# Altium schematic sheet Main.SchDoc(U_Main)
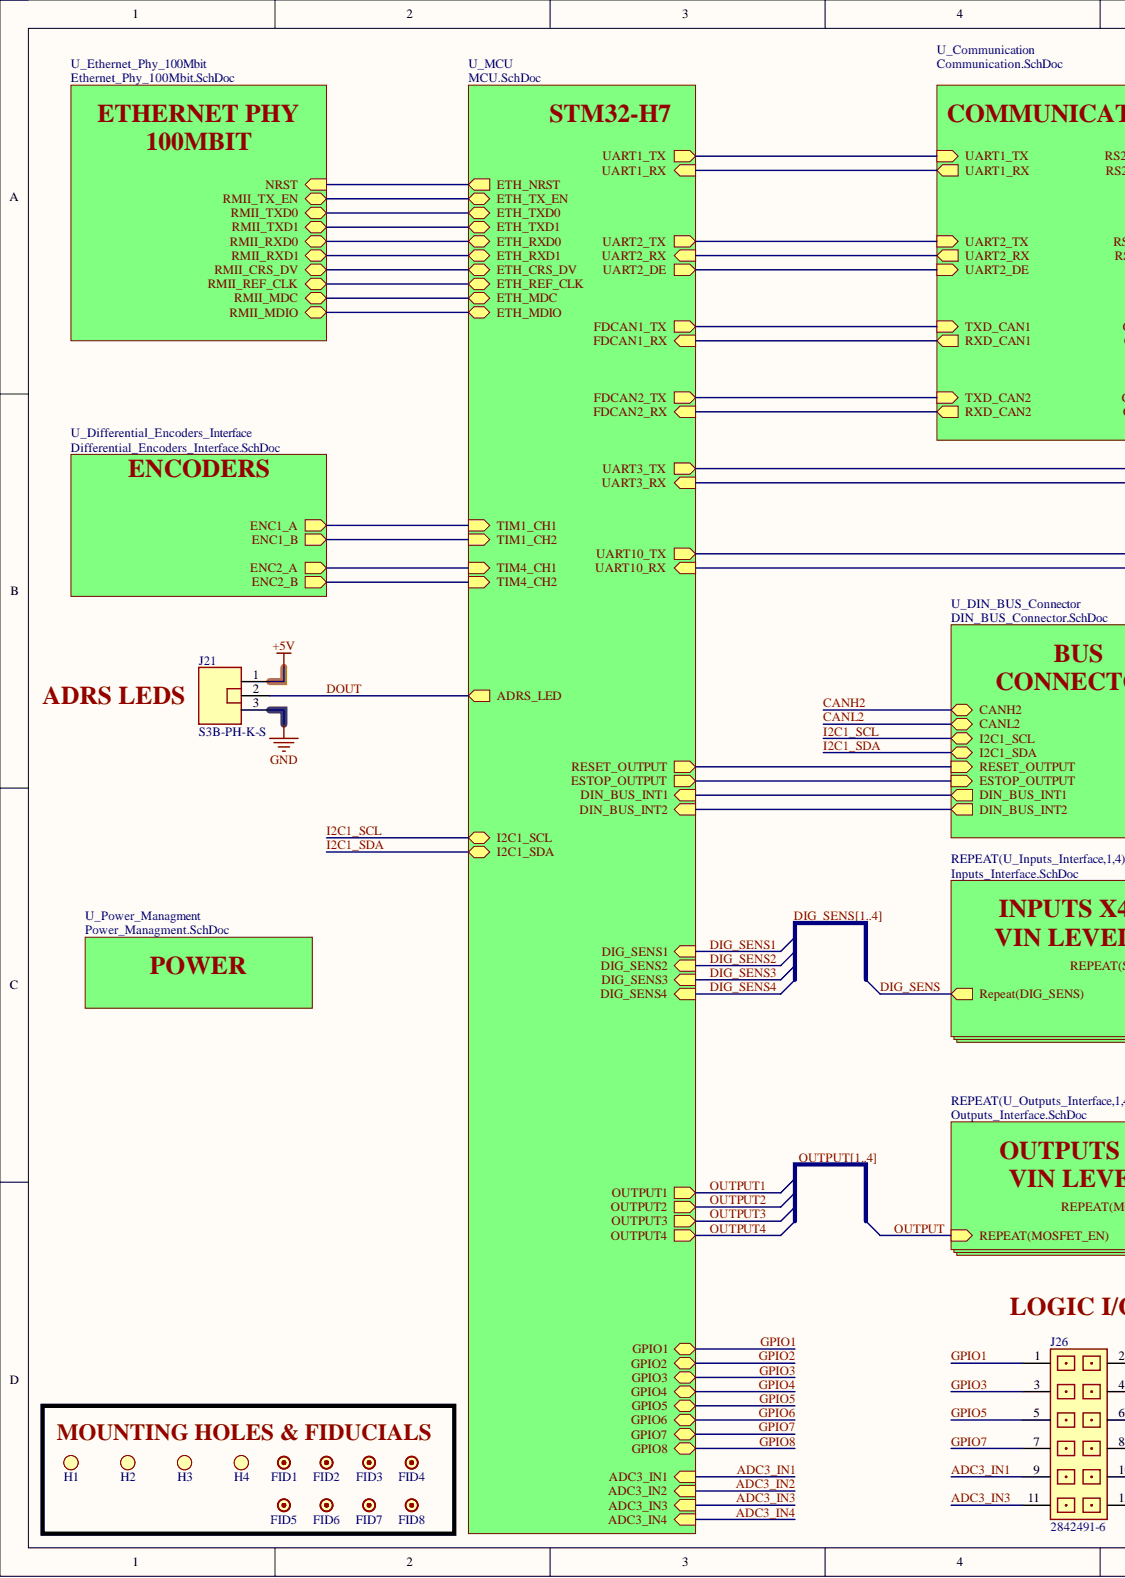
[diagram p0: Main.SchDoc, left]
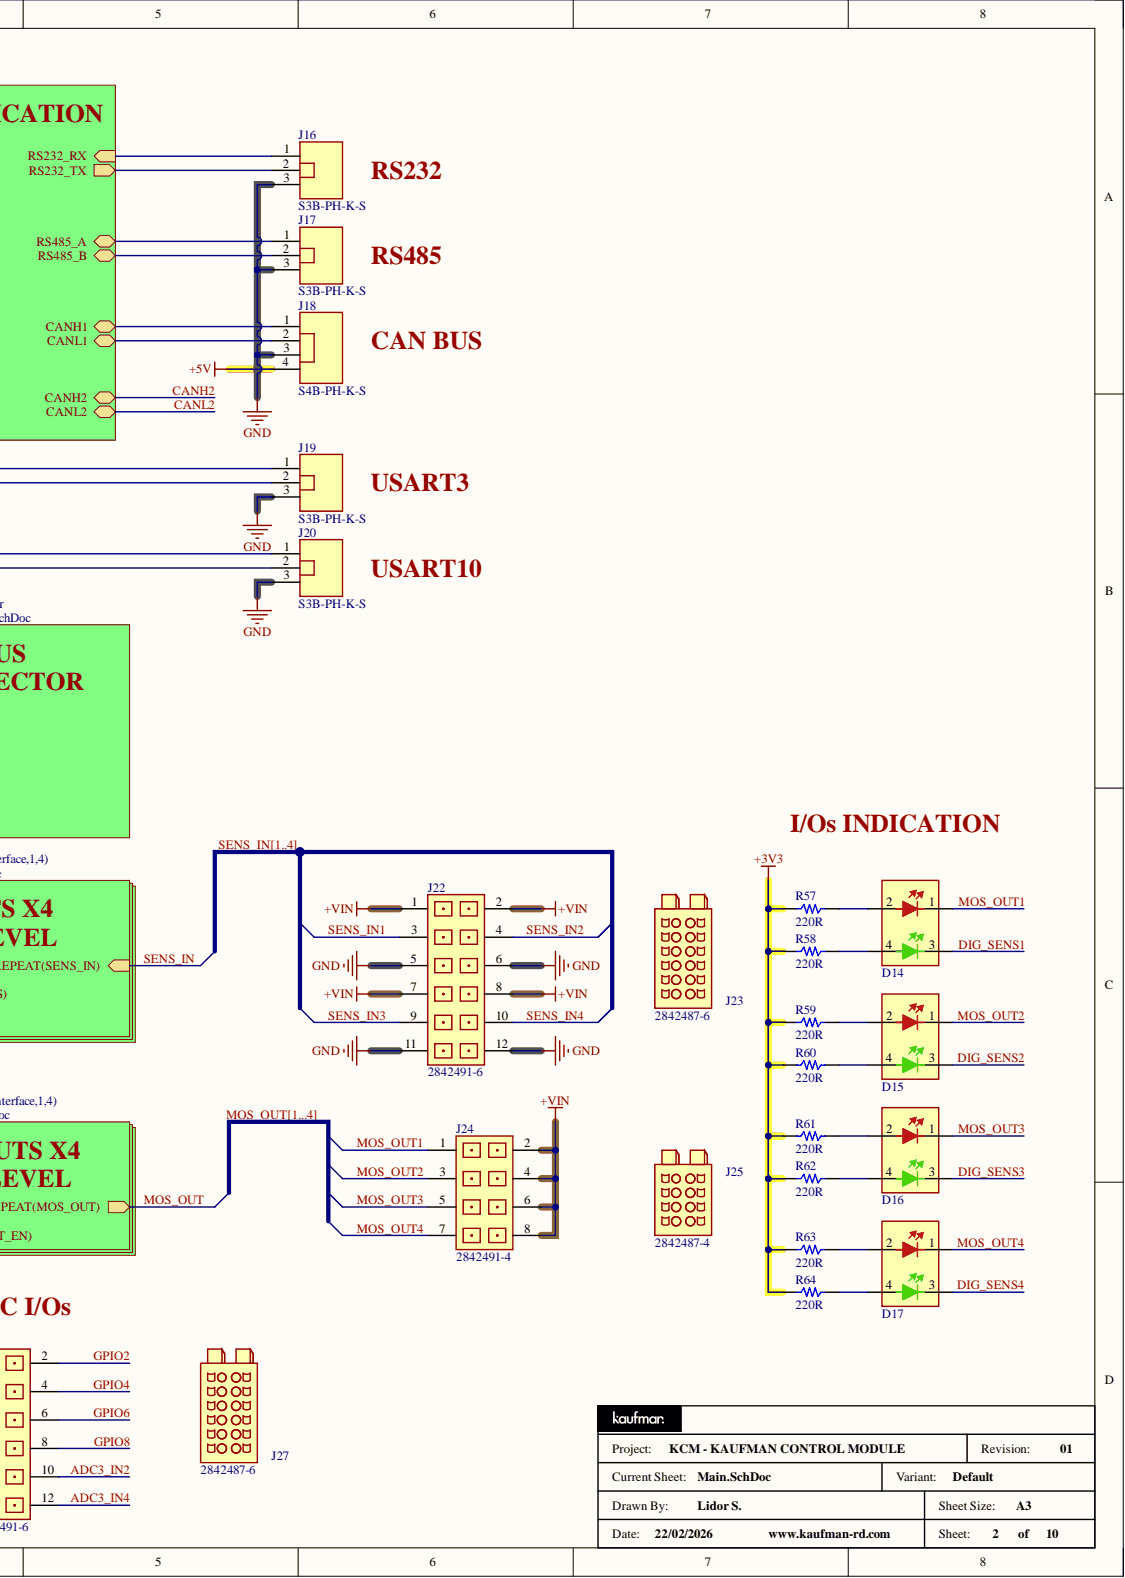
[diagram p1: Main.SchDoc, right]

## Parts
D14  WP934MD/LILGD  [WP934MD/LILGD]  (value not drawn)
D15  WP934MD/LILGD  [WP934MD/LILGD]  (value not drawn)
D16  WP934MD/LILGD  [WP934MD/LILGD]  (value not drawn)
D17  WP934MD/LILGD  [WP934MD/LILGD]  (value not drawn)
FID1  FIDUCIAL  [FIDUCIAL - 1mm]  (no BOM)
FID2  FIDUCIAL  [FIDUCIAL - 1mm]  (no BOM)
FID3  FIDUCIAL  [FIDUCIAL - 1mm]  (no BOM)
FID4  FIDUCIAL  [FIDUCIAL - 1mm]  (no BOM)
FID5  FIDUCIAL  [FIDUCIAL - 1mm]  (no BOM)
FID6  FIDUCIAL  [FIDUCIAL - 1mm]  (no BOM)
FID7  FIDUCIAL  [FIDUCIAL - 1mm]  (no BOM)
FID8  FIDUCIAL  [FIDUCIAL - 1mm]  (no BOM)
H1  MH3.2  [MoutingHole-M3.2_NonPlated]  (value not drawn)  (no BOM)
H2  MH3.2  [MoutingHole-M3.2_NonPlated]  (value not drawn)  (no BOM)
H3  MH3.2  [MoutingHole-M3.2_NonPlated]  (value not drawn)  (no BOM)
H4  MH3.2  [MoutingHole-M3.2_NonPlated]  (value not drawn)  (no BOM)
J16  S3B-PH-K-S  [S3B-PH-K-S]
J17  S3B-PH-K-S  [S3B-PH-K-S]
J18  S4B-PH-K-S  [S4B-PH-K-S]
J19  S3B-PH-K-S  [S3B-PH-K-S]
J20  S3B-PH-K-S  [S3B-PH-K-S]
J21  S3B-PH-K-S  [S3B-PH-K-S]
J22  2842491-6  [2842491-6]
J23  2842487-6  [2842487-6]
J24  2842491-4  [2842491-4]
J25  2842487-4  [2842487-4]
J26  2842491-6  [2842491-6]
J27  2842487-6  [2842487-6]
R57  value 220R  [RES0603]  (hidden comment: RC0603FR-07220RL)
R58  value 220R  [RES0603]  (hidden comment: RC0603FR-07220RL)
R59  value 220R  [RES0603]  (hidden comment: RC0603FR-07220RL)
R60  value 220R  [RES0603]  (hidden comment: RC0603FR-07220RL)
R61  value 220R  [RES0603]  (hidden comment: RC0603FR-07220RL)
R62  value 220R  [RES0603]  (hidden comment: RC0603FR-07220RL)
R63  value 220R  [RES0603]  (hidden comment: RC0603FR-07220RL)
R64  value 220R  [RES0603]  (hidden comment: RC0603FR-07220RL)

## Nets
+3V3: R57.2 R58.2 R59.2 R60.2 R61.2 R62.2 R63.2 R64.2
+5V: J18.4 J21.1
+VIN: J22.1 J22.2 J22.7 J22.8 J24.2 J24.4 J24.6 J24.8
GND: J16.3 J17.3 J18.3 J19.3 J20.3 J21.3 J22.5 J22.6 J22.11 J22.12
ADC3_IN1: J26.9
ADC3_IN2: J26.10
ADC3_IN3: J26.11
ADC3_IN4: J26.12
DIG_SENS1: D14.3  [also labelled DIG_SENS]
DIG_SENS2: D15.3  [also labelled DIG_SENS]
DIG_SENS3: D16.3  [also labelled DIG_SENS]
DIG_SENS4: D17.3  [also labelled DIG_SENS]
DOUT: J21.2
GPIO1: J26.1
GPIO2: J26.2
GPIO3: J26.3
GPIO4: J26.4
GPIO5: J26.5
GPIO6: J26.6
GPIO7: J26.7
GPIO8: J26.8
MOS_OUT1: D14.1 J24.1
MOS_OUT2: D15.1 J24.3
MOS_OUT3: D16.1 J24.5
MOS_OUT4: D17.1 J24.7
SENS_IN1: J22.3  [also labelled SENS_IN]
SENS_IN2: J22.4  [also labelled SENS_IN]
SENS_IN3: J22.9  [also labelled SENS_IN]
SENS_IN4: J22.10  [also labelled SENS_IN]
NetD14_2: D14.2 R57.1
NetD14_4: D14.4 R58.1
NetD15_2: D15.2 R59.1
NetD15_4: D15.4 R60.1
NetD16_2: D16.2 R61.1
NetD16_4: D16.4 R62.1
NetD17_2: D17.2 R63.1
NetD17_4: D17.4 R64.1
NetJ16_1: J16.1
NetJ16_2: J16.2
NetJ17_1: J17.1
NetJ17_2: J17.2
NetJ18_1: J18.1
NetJ18_2: J18.2
NetJ19_1: J19.1
NetJ19_2: J19.2
NetJ20_1: J20.1
NetJ20_2: J20.2
CANH2:
CANL2:
I2C1_SCL:
I2C1_SDA:
MOS_OUT[1...4]:  [also labelled MOS_OUT]
N00001:
N00002:
N00003:
N00004:
N00005:
N00006:
N00007:
N00008:
N00009:
N00010:
N00011:
N00012:
N00013:
N00014:
N00015:
N00016:
N00017:
N00018:
N00019:
N00020:
N00021:
N00022:
N00023:
N00024:
N00025:
N00026:
N00027:
OUTPUT1:  [also labelled OUTPUT]
OUTPUT2:  [also labelled OUTPUT]
OUTPUT3:  [also labelled OUTPUT]
OUTPUT4:  [also labelled OUTPUT]

## Library data of the parts
D14-D17 = WP934MD/LILGD: LED INDICATION GRN/RED, CBI 2.9MM BI-LVL, R/A; Manufacturer: Kingbright; Component Kind: Standard; ComponentLink1Description: Datasheet; ComponentLink1URL: https://www.kingbrightusa.com/images/catalog/SPEC/WP934MD-LILGD.pdf; ComponentLink2Description: DigiKey Link; ComponentLink2URL: https://www.digikey.com/en/products/detail/kingbright/WP934MD-LILGD/6003261; ComponentLink3Description: LCSC Link; ComponentLink3URL: https://www.lcsc.com/product-detail/C5367355.html?s_z=n_WP934MD%252FLILGD; DigiKey PN: 754-2010-ND; LCSC PN: C5367355; Library Name: 05-Optoelectronics.IntLib; MPN: WP934MD/LILGD
FID1-FID8 = FIDUCIAL: FIDUCIAL 1mm; Library Name: 09-TestPoints_And_Pads.IntLib
H1-H4 = MountingHole M3.2-NonPlated: Description: MountingHole M3.2-NonPlated; Library Name: 10-Mechanical.IntLib
J16 J17 J19-J21 = S3B-PH-K-S: JST PH Series 2.00mm - 3POS TH HORIZONTAL; Component Kind: Standard; ComponentLink1Description: Datasheet; ComponentLink1URL: https://www.jst-mfg.com/product/pdf/eng/ePH.pdf; ComponentLink2Description: DigiKey Link; ComponentLink2URL: https://www.digikey.com/en/products/detail/jst-sales-america-inc/S3B-PH-K-S/926627; ComponentLink3Description: LCSC Link; ComponentLink3URL: https://lcsc.com/product-detail/Wire-To-Board-Connector_JST-S3B-PH-K-S-LF-SN_C157929.html?s_z=n_S3B-PH-K-S; DigiKey PN: 455-1720-ND; LCSC PN: C157929; Library Name: 11-Connectors_2.00mm.IntLib; Manufacturer: JST; MPN: S3B-PH-K-S; Series: PH
J18 = S4B-PH-K-S: JST PH Series 2.00mm - 4POS TH HORIZONTAL; Component Kind: Standard; ComponentLink1Description: Datasheet; ComponentLink1URL: https://www.jst-mfg.com/product/pdf/eng/ePH.pdf; ComponentLink2Description: DigiKey Link; ComponentLink2URL: https://www.digikey.com/en/products/detail/jst-sales-america-inc/S4B-PH-K-S/926628; ComponentLink3Description: LCSC Link; ComponentLink3URL: https://lcsc.com/product-detail/Wire-To-Board-Connector_JST-S4B-PH-K-S-LF-SN_C157926.html?s_z=n_S4B-PH-K-S; DigiKey PN: 455-1721-ND; LCSC PN: C157926; Library Name: 11-Connectors_2.00mm.IntLib; Manufacturer: JST; MPN: S4B-PH-K-S; Series: PH
J22 J26 = 2842491-6: HEADER 12POS 3.5MM, Horizontal - TE; Manufacturer: TE Connectivity AMP Connectors; Mating Plug MPN: 2842487-6; Component Kind: Standard; ComponentLink1Description: Datasheet; ComponentLink1URL: https://www.te.com/commerce/DocumentDelivery/DDEController?Action=srchrtrv&DocNm=push-in-pcb-terminal-block-connectors&DocType=Data+Sheet&DocLang=English&DocFormat=pdf&PartCntxt=2842491-6; ComponentLink2Description: DigiKey Link; ComponentLink2URL: https://www.digikey.com/en/products/detail/te-connectivity-amp-connectors/2842491-6/25588190; ComponentLink3Description: LCSC Link; DigiKey PN: 17-2842491-6-ND; Library Name: 11-Connectors_3.5mm.IntLib; MPN: 2842491-6
J23 J27 = 2842487-6: TERM BLOCK PLUG 12POS 3.50MM, Screwless; Manufacturer: TE Connectivity AMP Connectors; Component Kind: Standard; ComponentLink1Description: Datasheet; ComponentLink1URL: https://www.te.com/commerce/DocumentDelivery/DDEController?Action=srchrtrv&DocNm=push-in-pcb-terminal-block-connectors&DocType=Data+Sheet&DocLang=English&DocFormat=pdf&PartCntxt=2842487-6; ComponentLink2Description: DigiKey Link; ComponentLink2URL: https://www.digikey.com/en/products/detail/te-connectivity-amp-connectors/2842487-6/25588053; ComponentLink3Description: LCSC Link; DigiKey PN: 17-2842487-6-ND; Library Name: 11-Connectors_3.5mm.IntLib; MPN: 2842487-6
J24 = 2842491-4: HEADER 8POS 3.5MM, Horizontal - TE; Manufacturer: TE Connectivity AMP Connectors; Mating Plug MPN: 2842487-4; Component Kind: Standard; ComponentLink1Description: Datasheet; ComponentLink1URL: https://www.te.com/commerce/DocumentDelivery/DDEController?Action=srchrtrv&DocNm=push-in-pcb-terminal-block-connectors&DocType=Data+Sheet&DocLang=English&DocFormat=pdf&PartCntxt=2842491-4; ComponentLink2Description: DigiKey Link; ComponentLink2URL: https://www.digikey.com/en/products/detail/te-connectivity-amp-connectors/2842491-4/25587942; ComponentLink3Description: LCSC Link; DigiKey PN: 17-2842491-4-ND; Library Name: 11-Connectors_3.5mm.IntLib; MPN: 2842491-4
J25 = 2842487-4: TERM BLOCK PLUG 8POS 3.50MM, Screwless; Manufacturer: TE Connectivity AMP Connectors; Component Kind: Standard; ComponentLink1Description: Datasheet; ComponentLink1URL: https://www.te.com/commerce/DocumentDelivery/DDEController?Action=srchrtrv&DocNm=push-in-pcb-terminal-block-connectors&DocType=Data+Sheet&DocLang=English&DocFormat=pdf&PartCntxt=2842487-4; ComponentLink2Description: DigiKey Link; ComponentLink2URL: https://www.digikey.com/en/products/detail/te-connectivity-amp-connectors/2842487-4/25588259; ComponentLink3Description: LCSC Link; DigiKey PN: 17-2842487-4-ND; Library Name: 11-Connectors_3.5mm.IntLib; MPN: 2842487-4
R57-R64 = RC0603FR-07220RL: 220 Ohms ±1% 0.1W, 1/10W Chip Resistor 0603 (1608 Metric) Moisture Resistant Thick Film; Manufacturer: YAGEO; Component Kind: Standard; ComponentLink1Description: Datasheet; ComponentLink1URL: https://www.yageo.com/upload/media/product/products/datasheet/rchip/PYu-RC_Group_51_RoHS_L_12.pdf; ComponentLink2Description: DigiKey Link; ComponentLink2URL: https://www.digikey.com/en/products/detail/yageo/RC0603FR-07220RL/727061?s=N4IgTCBcDaIEoGEAMA2JBmAYnAtEg7GGEnADIgC6AvkA; ComponentLink3Description: LCSC Link; ComponentLink3URL: https://lcsc.com/product-detail/Chip-Resistor-Surface-Mount_YAGEO-RC0603FR-07220RL_C107696.html?s_z=n_RC0603FR-07220RL; DigiKey PN: 311-220HRCT-ND; LCSC PN: C107696; Library Name: 00-Resistors_0603.IntLib; MPN: RC0603FR-07220RL; Package: 0603
